# Altium schematic sheet 2407_Affichage.SchDoc
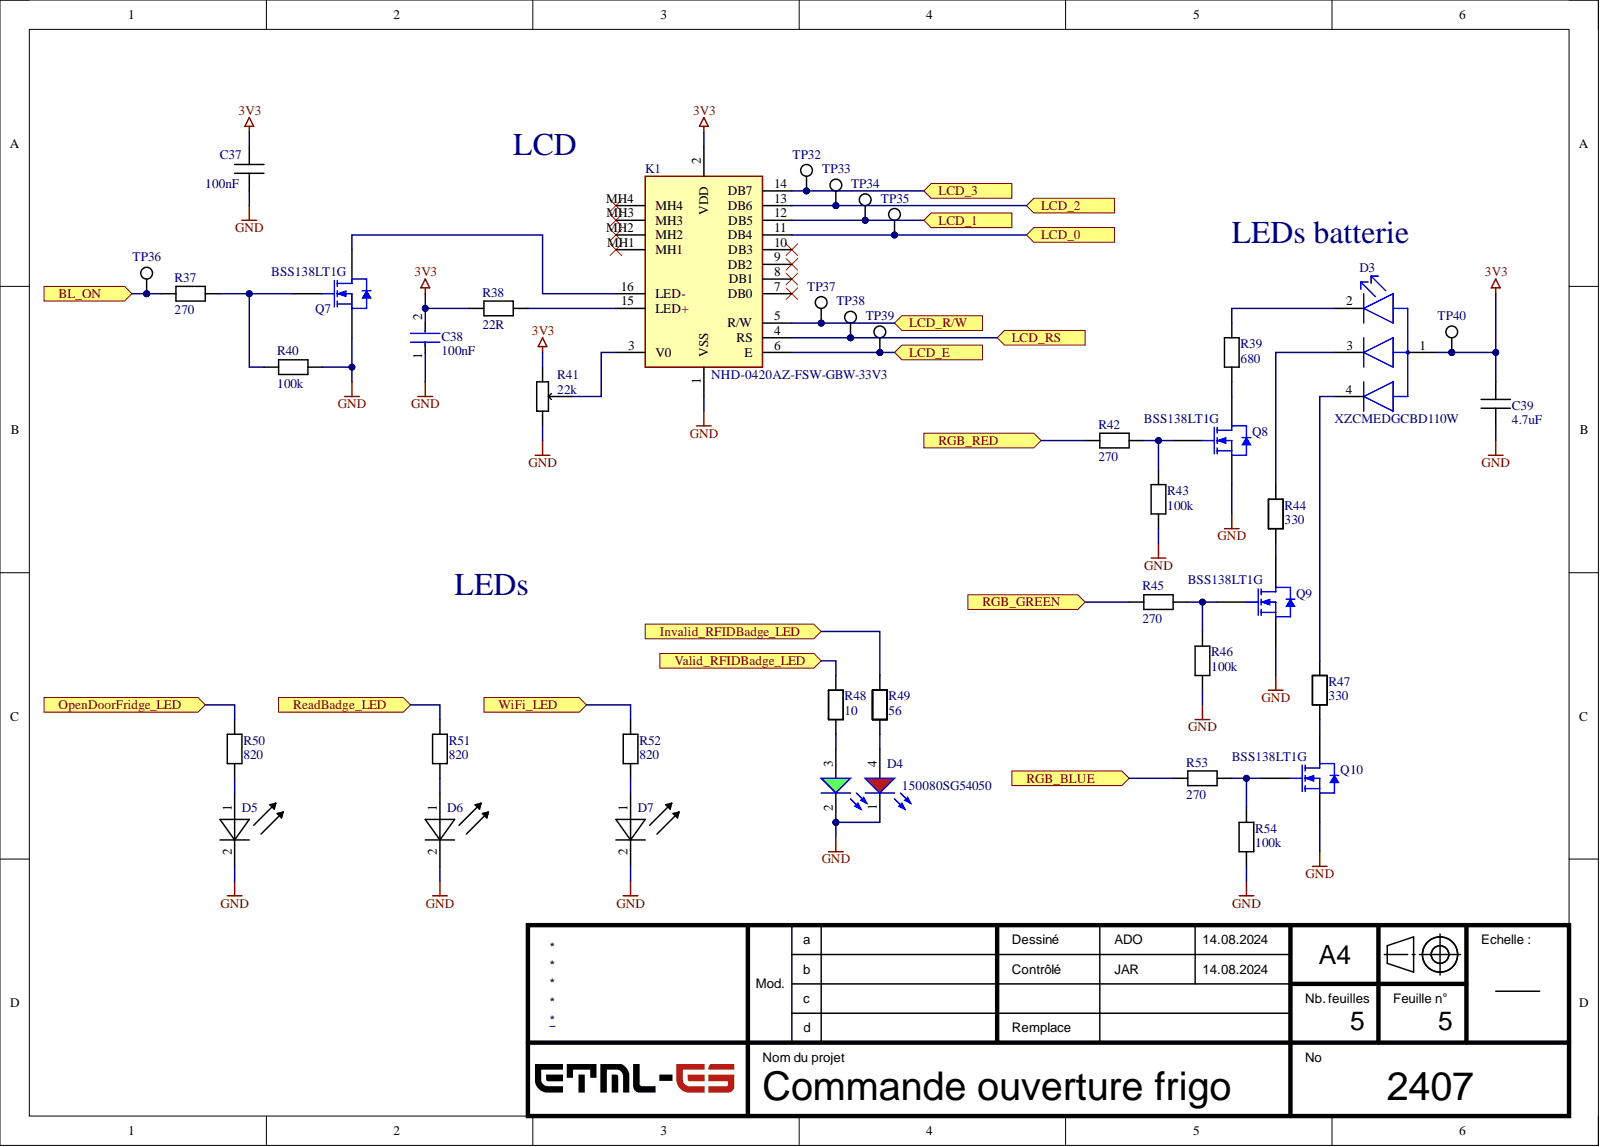
[diagram p0: 2407_Affichage.SchDoc]

## Parts
C37  100nF  [CAP0805]
C38  100nF  [FP-CC0805-0_85-MFG]
C39  4.7uF  [CAP0805]
D3  XZCMEDGCBD110W  [FP-XZCMEDGCBD110W-MFG]
D4  150080SG54050  [WL-SBCD_0805]
D5  APTD2012LSURCK  [APTD2012LSURCK]  (value not drawn)
D6  APTD2012LSURCK  [APTD2012LSURCK]  (value not drawn)
D7  APTD2012LSURCK  [APTD2012LSURCK]  (value not drawn)
K1  NHD-0420AZ-FSW-GBW-33V3  [NHD-0420AZ-FSW-GBW-33V3]
Q7  BSS138LT1G  [ONSC-SOT-23-3-318-08_V]
Q8  BSS138LT1G  [ONSC-SOT-23-3-318-08_V]
Q9  BSS138LT1G  [ONSC-SOT-23-3-318-08_V]
Q10  BSS138LT1G  [ONSC-SOT-23-3-318-08_V]
R37  270  [0805R]
R38  22R  [0805R]
R39  680  [0805R]
R40  100k  [0805R]
R41  22k  [3386P-1-223LF]
R42  270  [0805R]
R43  100k  [0805R]
R44  330  [0805R]
R45  270  [0805R]
R46  100k  [0805R]
R47  330  [0805R]
R48  10  [0805R]
R49  56  [0805R]
R50  820  [0805R]
R51  820  [0805R]
R52  820  [0805R]
R53  270  [0805R]
R54  100k  [0805R]
TP32  5019  [KSTN-5019_V]  (value not drawn)
TP33  5019  [KSTN-5019_V]  (value not drawn)
TP34  5019  [KSTN-5019_V]  (value not drawn)
TP35  5019  [KSTN-5019_V]  (value not drawn)
TP36  5019  [KSTN-5019_V]  (value not drawn)
TP37  5019  [KSTN-5019_V]  (value not drawn)
TP38  5019  [KSTN-5019_V]  (value not drawn)
TP39  5019  [KSTN-5019_V]  (value not drawn)
TP40  5019  [KSTN-5019_V]  (value not drawn)

## Ports
BL_ON, Invalid_RFIDBadge_LED, LCD_0, LCD_1, LCD_2, LCD_3, LCD_E, LCD_R/W, LCD_RS, OpenDoorFridge_LED, ReadBadge_LED, RGB_BLUE, RGB_GREEN, RGB_RED, Valid_RFIDBadge_LED, WiFi_LED

## Nets
3V3: C37.2 C38.2 C39.2 D3.1 K1.2(VDD) R38.1 R41.1 TP40.1
GND: C37.1 C38.1 C39.1 D4.1 D4.2 D5.2 D6.2 D7.2 K1.1(VSS) Q7.2 Q8.2 Q9.2 Q10.2 R40.2 R41.3 R43.1 R46.1 R54.1
BL_ON: R37.1 TP36.1 ; port BL_ON
Invalid_RFIDBadge_LED: R49.2 ; port Invalid_RFIDBadge_LED
LCD_0: K1.11(DB4) TP35.1 ; port LCD_0
LCD_1: K1.12(DB5) TP34.1 ; port LCD_1
LCD_2: K1.13(DB6) TP33.1 ; port LCD_2
LCD_3: K1.14(DB7) TP32.1 ; port LCD_3
LCD_E: K1.6(E) TP39.1 ; port LCD_E
LCD_R/W: K1.5(R/W) TP37.1 ; port LCD_R/W
LCD_RS: K1.4(RS) TP38.1 ; port LCD_RS
OpenDoorFridge_LED: R50.2 ; port OpenDoorFridge_LED
ReadBadge_LED: R51.2 ; port ReadBadge_LED
RGB_BLUE: R53.1 ; port RGB_BLUE
RGB_GREEN: R45.1 ; port RGB_GREEN
RGB_RED: R42.1 ; port RGB_RED
Valid_RFIDBadge_LED: R48.2 ; port Valid_RFIDBadge_LED
WiFi_LED: R52.2 ; port WiFi_LED
NetD3_2: D3.2 R39.2
NetD3_3: D3.3 R44.2
NetD3_4: D3.4 R47.2
NetD4_3: D4.3 R48.1
NetD4_4: D4.4 R49.1
NetD5_1: D5.1 R50.1
NetD6_1: D6.1 R51.1
NetD7_1: D7.1 R52.1
NetK1_3: K1.3(V0) R41.2
NetK1_15: K1.15(LED+) R38.2
NetK1_16: K1.16(LED-) Q7.3
NetQ7_1: Q7.1 R37.2 R40.1
NetQ8_1: Q8.1 R42.2 R43.2
NetQ8_3: Q8.3 R39.1
NetQ9_1: Q9.1 R45.2 R46.2
NetQ9_3: Q9.3 R44.1
NetQ10_1: Q10.1 R53.2 R54.2
NetQ10_3: Q10.3 R47.1

Not connected: K1 7(DB0) 8(DB1) 9(DB2) 10(DB3) MH1 MH2 MH3 MH4

## Library data of the parts
C38 = CMP-2100-03606-3: CAP CER 0.1UF 50V X7R 0805; Manufacturer: Yageo; Manufacturer Part Number: CC0805KRX7R9BB104; Material: Ceramic; Tolerance: 0.1; Automotive: No; Capacitance: 100 nF; Category: Cap; Component Kind: Standard; ComponentLink2Description: Datasheet; ComponentLink2URL: http://www.yageo.com/documents/recent/UPY-GPHC_Y5V_6.3V-to-50V_8.pdf; Device Class L1: Passive Components; Device Class L2: Capacitors; Device Class L3: Ceramic Capacitors; Digikey Description: CAP CER 0.1UF 50V X7R 0805; Footprint Url: http://www.yageo.com/exep/pages/download/literatures/UPY-C_GEN_24.pdf; Height: 0.95mm; Ipc Land Pattern Name: CAPC200125X85; Lead Free: Yes; Library Name: Altium Content Vault; Mouser Description: Multilayer Ceramic Capacitors MLCC - SMD/SMT 100nF 50V X7R 10%; Octopart: https://octopart.com/cc0805krx7r9bb104-yageo-192993; Package: CC0805-0.85; RoHS: TRUE; Temperature: -30°C to +85°C; Temperature Characteristic: X7R; Temperature Range High: +85°C; Temperature Range Low: -30°C; Value: 100 nF; Voltage Rating: 50 V
D3 = CMP-92166-000004-1: 1.6X1.6MM LC RGB FULL COLOR SMD; Component Kind: Standard; Library Name: Altium Content Vault; RoHS Compliant: Yes
D4 = CMP-03913-108242110-1: 150080SG54050 Led, Red/Grn, 100Mcd/230Mcd, 630Nm/525Nm Rohs Compliant: Yes; Dominant Wavelength: 525nm; Forward Current: 40mA; Forward Voltage: 2V; Luminous Intensity: 230mcd; Max Reverse Voltage (DC): 5V; Peak Wavelength: 520nm; Viewing Angle: 130°; Component Kind: Standard; Height: 500µm; Length: 2mm; Library Name: Altium Content Vault; Max Operating Temperature: 85°C; Min Operating Temperature: -40°C; NexarPartId: 108242110; RoHS: Compliant; Width: 1.3mm
Q7-Q10 = CMP-1058-00767-1: Power MOSFET, 200 mA, 50 V, N-Channel, 3-Pin SOT-23, Pb-Free, Tape and Reel; Manufacturer: On Semiconductor; Channel Polarity: N Channel; Ciss Typ (pF): 40; Configuration: Single; Coss Typ (pF): 12; Crss Typ (pF): 3.5; ID Max (A): 0.2; PD Max (W): 0.225; V(BR)DSS Min (V): 50; VGS Max (V): 20; VGS(th) Max (V): 1.5; Component Kind: Standard; ComponentLink1Description: Manufacturer URL; ComponentLink1URL: http://www.onsemi.com/; ComponentLink2Description: Datasheet; ComponentLink2URL: http://www.onsemi.com/pub_link/Collateral/BSS138LT1-D.PDF; ComponentLink3Description: Package Specification; ComponentLink3URL: http://www.onsemi.cn/pub_link/Collateral/318-08.PDF; DatasheetVersion: Rev. 8; Library Name: Altium Content Vault; Mounting Technology: Surface Mount; Package Type: SOT-23-3; PackageDescription: 3-Pin Small Outline Transistor, Body 2.92 x 1.3 mm, Pitch 0.95 mm; PackageReference: SOT-23-3-318-08; PackageVersion: Rev. AP, 11/2009; Packing: Tape and Reel; PartNumber: BSS138LT1G; RoHS: Pb-Free
R37 R42 R45 R53 = 270R: Component Kind: Standard; Library Name: 2407_CommandeOuvertureFrigo.SchLib
R39 = 680R: Component Kind: Standard; Library Name: 2407_CommandeOuvertureFrigo.SchLib
R41 = 3386P-1-223LF: Component Kind: Standard; Library Name: Schlib1.SchLib
R44 R47 = 330R: Component Kind: Standard; Library Name: 2407_CommandeOuvertureFrigo.SchLib
R48 = 10R: Component Kind: Standard; Library Name: 2407_CommandeOuvertureFrigo.SchLib
R49 = 56R: Component Kind: Standard; Library Name: 2407_CommandeOuvertureFrigo.SchLib
R50-R52 = 820R: Component Kind: Standard; Library Name: 2407_CommandeOuvertureFrigo.SchLib
TP32-TP40 = CMP-1672-00008-1: Test Point, 1 Position SMD, RoHS, Tape and Reel; Component Kind: Standard; ComponentLink1Description: Manufacturer URL; ComponentLink1URL: http://www.keyelco.com/; ComponentLink2Description: Datasheet; ComponentLink2URL: http://www.keyelco.com/product-pdf.cfm?p=1357; Contact Plating: Silver; DatasheetVersion: 03/2014; Library Name: Altium Content Vault; Mount: Surface Mount; Mounting Technology: Surface Mount; Package Quantity: 1000; PackageDescription: 1-Pin Surface Mount Device, Body 3.81 x 2.03 mm; PackageReference: 5019; Packaging: Tape and Reel; Pins: 1; RoHSCompliant: True
C37 = 100nF: Component Kind: Standard; Library Name: 2407_CommandeOuvertureFrigo.SchLib
C39 = 4.7uF: Component Kind: Standard; Library Name: 2407_CommandeOuvertureFrigo.SchLib
D5-D7 = APTD2012LSURCK: Component Kind: Standard; Library Name: 2407_CommandeOuvertureFrigo.SchLib
K1 = NHD-0420AZ-FSW-GBW-33V3: Component Kind: Standard; Library Name: 2407_CommandeOuvertureFrigo.SchLib
R38 = 22R: Component Kind: Standard; Library Name: 2407_CommandeOuvertureFrigo.SchLib
R40 R43 R46 R54 = 100k: Component Kind: Standard; Library Name: 2407_CommandeOuvertureFrigo.SchLib
